# Altium schematic sheet [08] PCIe.SchDoc(BLK4)
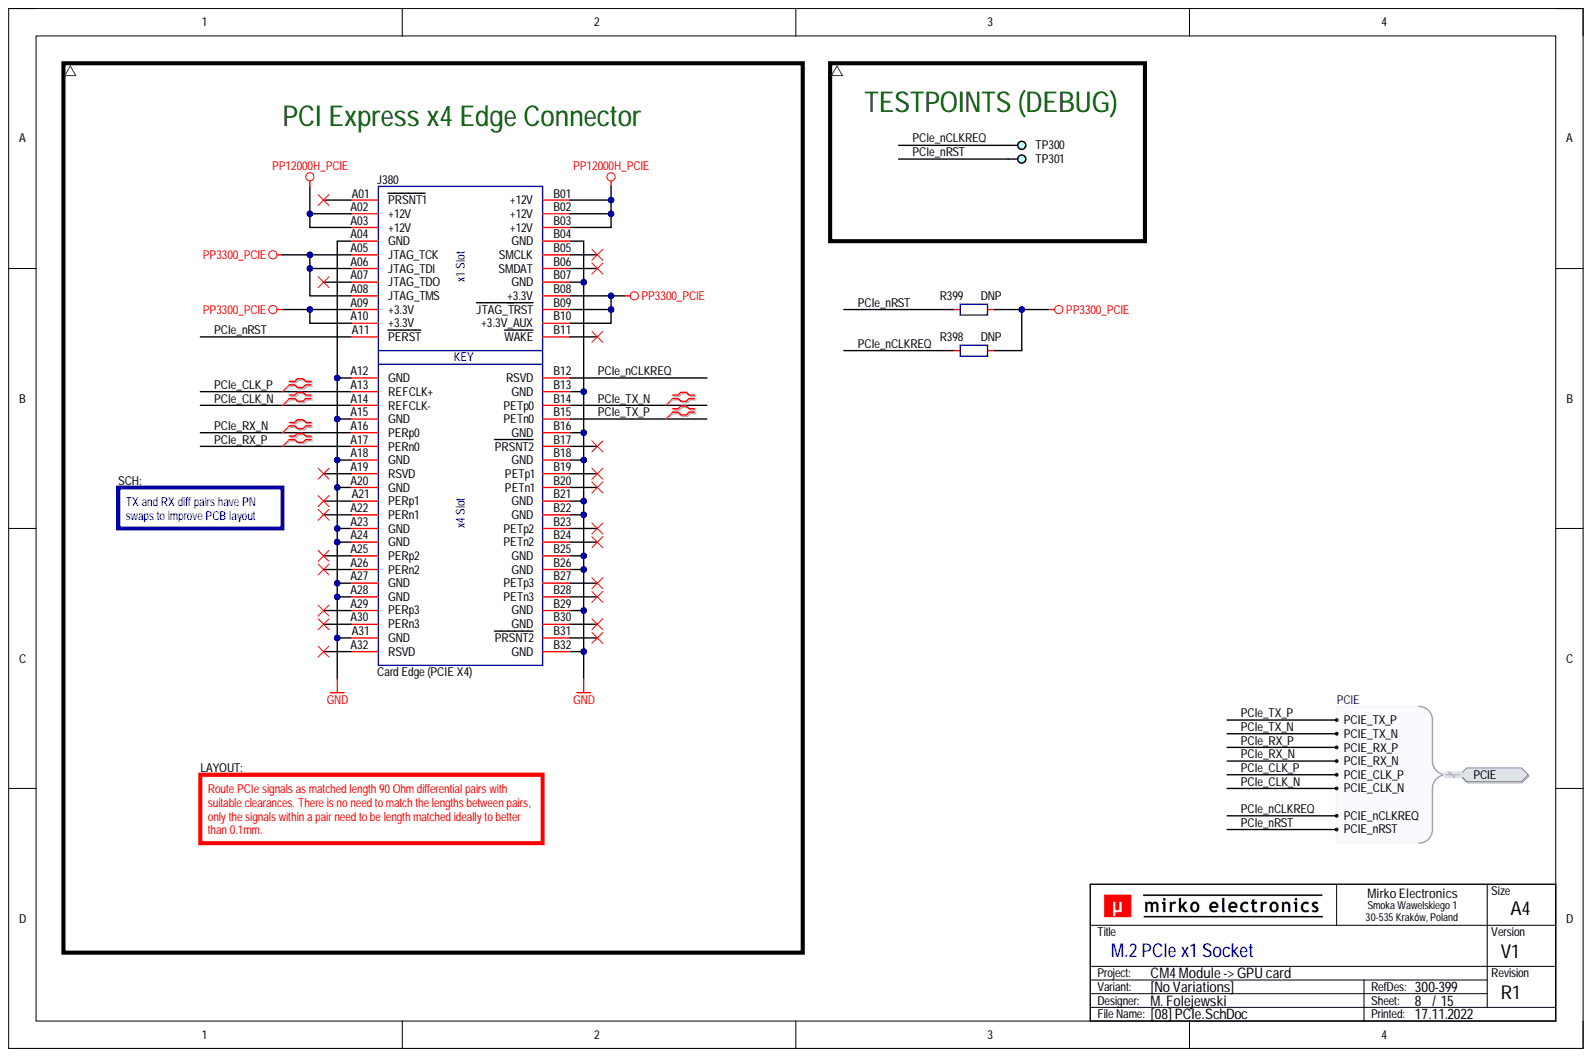
[diagram p0: [08] PCIe.SchDoc]

## Parts
J380  Card Edge (PCIE X4)  [PCB_CARD_EDGE_PCIE_64P]  (no BOM)
R398  DNP  [RES_0603_R]
R399  DNP  [RES_0603_R]
TP300  DEBUG  [PCB_TP_DBG_50]  (no BOM)
TP301  DEBUG  [PCB_TP_DBG_50]  (value not drawn)  (no BOM)

## Ports
PCIE, PCIE.PCIE_CLK_N, PCIE.PCIE_CLK_P, PCIE.PCIE_nCLKREQ, PCIE.PCIE_nRST, PCIE.PCIE_RX_N, PCIE.PCIE_RX_P, PCIE.PCIE_TX_N, PCIE.PCIE_TX_P

## Nets
GND: J380.A04(GND) J380.A12(GND) J380.A15(GND) J380.A18(GND) J380.A20(GND) J380.A23(GND) J380.A24(GND) J380.A27(GND) J380.A28(GND) J380.A31(GND) J380.B04(GND) J380.B07(GND) J380.B13(GND) J380.B16(GND) J380.B18(GND) J380.B21(GND) J380.B22(GND) J380.B25(GND) J380.B26(GND) J380.B29(GND) J380.B32(GND)
PP3300_PCIE: J380.A05(JTAG_TCK) J380.A06(JTAG_TDI) J380.A08(JTAG_TMS) J380.A09(+3.3V) J380.A10(+3.3V) J380.B08(+3.3V) J380.B09(JTAG_TRST) J380.B10(+3.3V_AUX) R398.1 R399.1
PP12000H_PCIE: J380.A02(+12V) J380.A03(+12V) J380.B01(+12V) J380.B02(+12V) J380.B03(+12V)
PCIe_CLK_N: J380.A14(REFCLK-) ; port PCIE
PCIe_CLK_P: J380.A13(REFCLK+) ; port PCIE
PCIe_nCLKREQ: J380.B12(RSVD) R398.2 TP300.1 ; port PCIE
PCIe_nRST: J380.A11(PERST) R399.2 TP301.1 ; port PCIE
PCIe_RX_N: J380.A16(PERp0) ; port PCIE
PCIe_RX_P: J380.A17(PERn0) ; port PCIE
PCIe_TX_N: J380.B14(PETp0) ; port PCIE
PCIe_TX_P: J380.B15(PETn0) ; port PCIE

Not connected: J380 A01(PRSNT1) A07(JTAG_TDO) A19(RSVD) A21(PERp1) A22(PERn1) A25(PERp2) A26(PERn2) A29(PERp3) A30(PERn3) A32(RSVD) B05(SMCLK) B06(SMDAT) B11(WAKE) B17(PRSNT2) B19(PETp1) B20(PETn1) B23(PETp2) B24(PETn2) B27(PETp3) B28(PETn3) B30(GND) B31(PRSNT2)

## Library data of the parts
J380 = CON_PCIE_64P_VAR2: Connector; PCIE, x4 (64pin); Library Name: Adapter_X4_Lib.SchLib; Manufacturer: N/A; MPN: N/A; Value: Card Edge (PCIE X4)
R398 R399 = RES-0054: Resistor; Thick Film; GENERIC; TBD; TBD; TBD; TBD; TBD; SMD; 0603 (1608);; Database Table Name: RESISTOR$; Component Kind: Standard; Component Subtype: Thick Film; Component Type: Resistor; Manufacturer: GENERIC; Mounting Type: SMD; MPN: GENERIC; Package (Case): 0603 (1608); Supplier: ?; Value: DNP; Library Name: MirFol_db_local.DbLib
TP300 TP301 = PCB_TP_DBG: PCB Marking; Test Point (Debug);; Component Subtype: -; Component Type: Testpoint; Mounting Type: -; Supplier: ?; Value: DEBUG; Library Name: MirFol_lib.SchLib
